# Altium schematic sheet Main.SchDoc
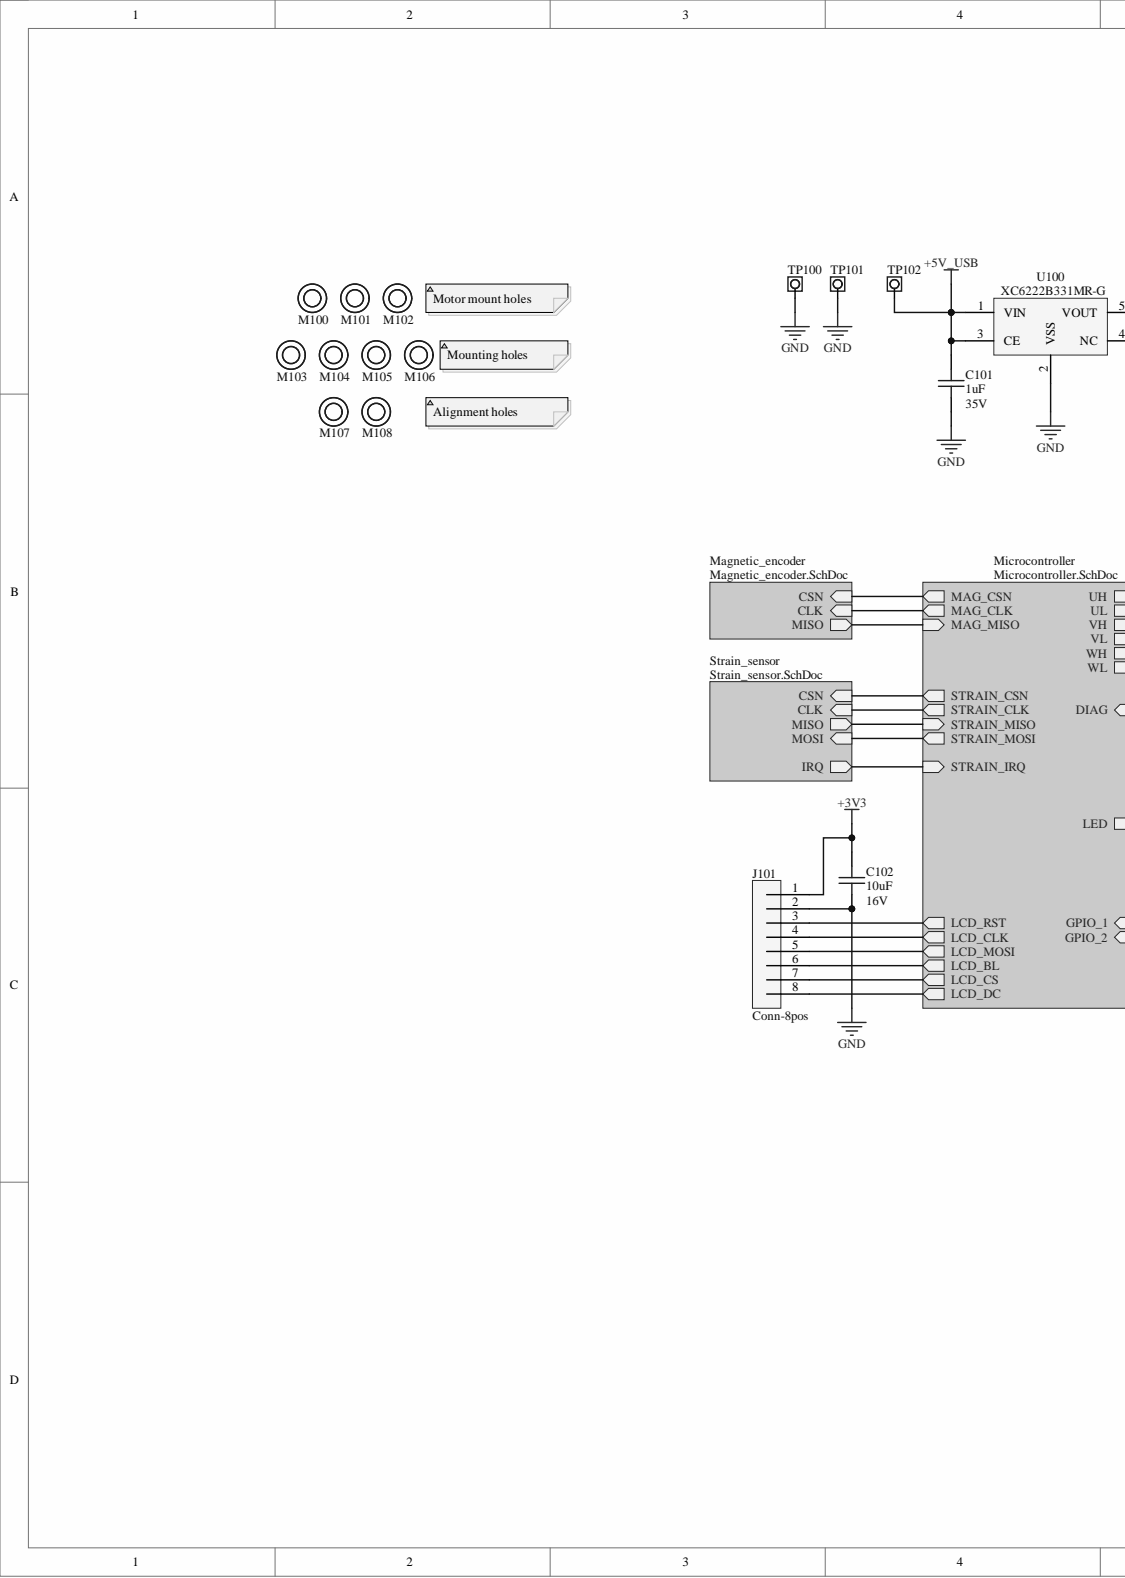
[diagram p0: Main.SchDoc, left]
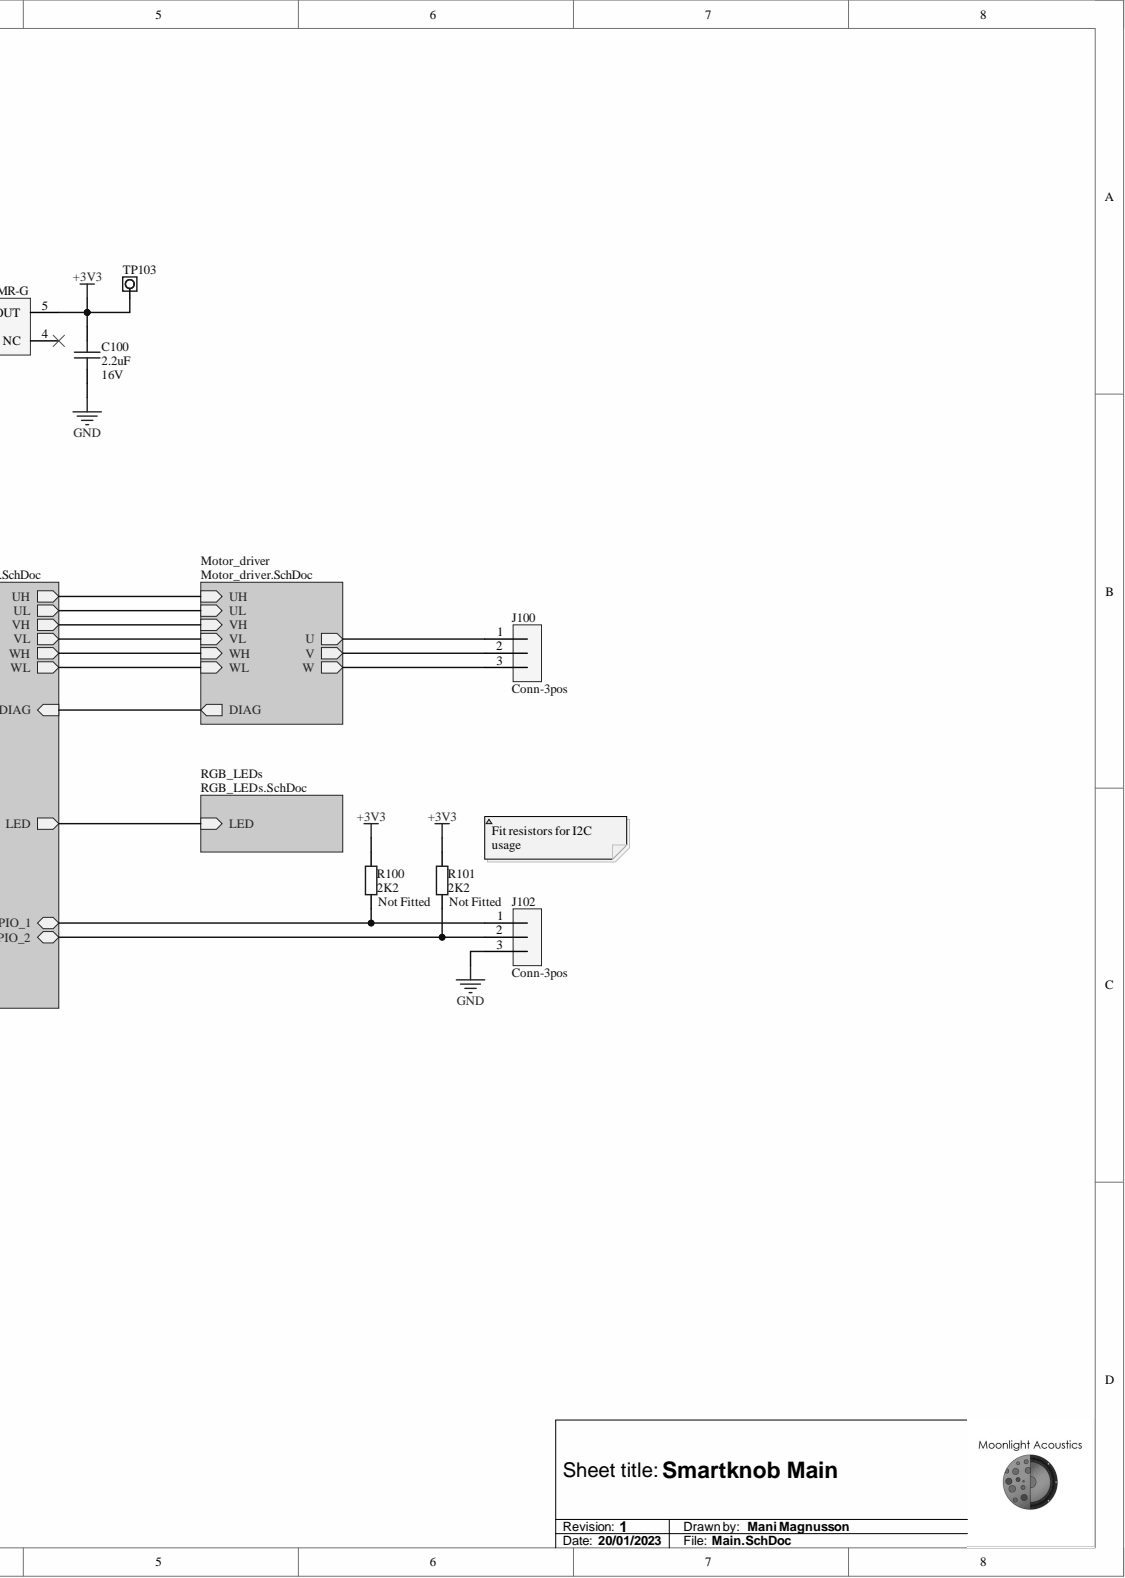
[diagram p1: Main.SchDoc, right]

## Parts
C100  value 2.2uF  Voltage: 16V  [C0805]  (hidden comment: CAP)
C101  value 1uF  Voltage: 35V  [C0603]  (hidden comment: CAP)
C102  value 10uF  Voltage: 16V  [C0805]  (hidden comment: CAP)
J100  Conn-3pos  [0532610371]
J101  Conn-8pos  [2mm_pads_8]
J102  Conn-3pos  [2.54mm_header_vertical_male]
M100  Mounting_Hole_Unplated  [M1.6_Close_Unplated]  (value not drawn)
M101  Mounting_Hole_Unplated  [M1.6_Close_Unplated]  (value not drawn)
M102  Mounting_Hole_Unplated  [M1.6_Close_Unplated]  (value not drawn)
M103  Mounting_Hole_Unplated  [M2_Normal_Unplated]  (value not drawn)
M104  Mounting_Hole_Unplated  [M2_Normal_Unplated]  (value not drawn)
M105  Mounting_Hole_Unplated  [M2_Normal_Unplated]  (value not drawn)
M106  Mounting_Hole_Unplated  [M2_Normal_Unplated]  (value not drawn)
M107  Mounting_Hole_Unplated  [M2_Close_Unplated]  (value not drawn)
M108  Mounting_Hole_Unplated  [M2_Close_Unplated]  (value not drawn)
R100  value 2K2  [R0603]  (hidden comment: RES)
R101  value 2K2  Fitted: Not Fitted  [R0603]  (hidden comment: RES)
TP100  Testpoint  [5019]  (value not drawn)
TP101  Testpoint  [5019]  (value not drawn)
TP102  Testpoint  [5019]  (value not drawn)
TP103  Testpoint  [5019]  (value not drawn)
U100  XC6222B331MR-G  [SOT-25-5]

## Nets
+3V3: C100.1 C102.1 J101.1 R100.2 R101.2 TP103.1 U100.5(VOUT)
+5V_USB: C101.1 TP102.1 U100.1(VIN) U100.3(CE)
GND: C100.2 C101.2 C102.2 J101.2 J102.3 TP100.1 TP101.1 U100.2(VSS)
NetJ100_1: J100.1
NetJ100_2: J100.2
NetJ100_3: J100.3
NetJ101_3: J101.3
NetJ101_4: J101.4
NetJ101_5: J101.5
NetJ101_6: J101.6
NetJ101_7: J101.7
NetJ101_8: J101.8
NetJ102_1: J102.1 R100.1
NetJ102_2: J102.2 R101.1
NetU100_4: U100.4(NC)
CLK:
CSN:
DIAG:
IRQ:
LED:
MAG_MISO:
MISO:
MOSI:
UH:
UL:
VH:
VL:
WH:
WL:

## Library data of the parts
C100 = CGA4J3X7R1C225K125AB: General purpose ceramic capacitor; Manufacturer: TDK Corporation; Database Table Name: Capacitors$; Ready for use: y; Specification: X7R, 16V, AEC-Q200 Qualified; Component Kind: Standard; Fitted: Fitted; Generic: Generic; Manufacturer Part No: CGA4J3X7R1C225K125AB; Package Ref: C0805; Part Reference: CGA4J3X7R1C225K125AB; Supplier: Digi-Key; Supplier Part No: 445-5691-1-ND; Library Name: Moonlight_Library.DbLib
C101 = CGA3E1X7R1V105K080AC: General purpose ceramic capacitor; Manufacturer: TDK Corporation; Database Table Name: Capacitors$; Ready for use: y; Specification: X7R, 35V, AEC-Q200 Qualified; Component Kind: Standard; Fitted: Fitted; Generic: Generic; Manufacturer Part No: CGA3E1X7R1V105K080AC; Package Ref: C0603; Part Reference: CGA3E1X7R1V105K080AC; Supplier: Digi-Key; Supplier Part No: 445-174215-1-ND; Library Name: Moonlight_Library.DbLib
C102 = CGA4J1X7S1C106K125AC: General purpose ceramic capacitor; Manufacturer: TDK Corporation; Database Table Name: Capacitors$; Ready for use: y; Specification: X7S, 16V, AEC-Q200 Qualified; Component Kind: Standard; Fitted: Fitted; Generic: Generic; Manufacturer Part No: CGA4J1X7S1C106K125AC; Package Ref: C0805; Part Reference: CGA4J1X7S1C106K125AC; Supplier: Digi-Key; Supplier Part No: 445-16110-1-ND; Library Name: Moonlight_Library.DbLib
J100 = 0532610371: 3 pin horizontal picoblade connector; Manufacturer: Molex; Database Table Name: Connectors$; Generic: Non Generic; Ready for use: y; Specification: 125 V 1 A max; Component Kind: Standard; Fitted: Fitted; Manufacturer Part No: 0532610371; Package Ref: 0532610371; Part Reference: 0532610371; Supplier: Digi-Key; Supplier Part No: WM7621CT-ND; Library Name: Moonlight_Library.DbLib
J101 = 2mm_pads_8: 2mm pitch solder pads; Database Table Name: Connectors$; Ready for use: n; Component Kind: Standard; Fitted: Fitted; Generic: Generic; Manufacturer Part No: 2mm_pads_8; Package Ref: 2mm_pads_8; Part Reference: 2mm_pads_8; Library Name: Moonlight_Library.DbLib
J102 = 61300311121: 3 pin vertical 2.54 mm header; Manufacturer: Würth Elektronik; Database Table Name: Connectors$; Ready for use: n; Specification: 250 V 3 A max; Component Kind: Standard; Fitted: Fitted; Generic: Generic; Manufacturer Part No: 61300311121; Package Ref: 2.54mm_header_vertical_male; Part Reference: 61300311121; Supplier: Digi-Key; Supplier Part No: 732-5316-ND; Library Name: Moonlight_Library.DbLib
M100-M102 = M1.6_Close_Unplated: M1.6 Hole, Unplated; Database Table Name: Non Electrical$; Ready for use: y; Specification: Close clearance; Component Kind: Standard; Fitted: Fitted; Generic: Generic; Package Ref: M1.6_Close_Unplated; Part Reference: M1.6_Close_Unplated; Library Name: Moonlight_Library.DbLib
M103-M106 = M2_Normal_Unplated: M2 Hole, Unplated; Database Table Name: Non Electrical$; Ready for use: y; Specification: Normal clearance; Component Kind: Standard; Fitted: Fitted; Generic: Generic; Package Ref: M2_Normal_Unplated; Part Reference: M2_Normal_Unplated; Library Name: Moonlight_Library.DbLib
M107 M108 = M2_Close_Unplated: M2 Hole, Unplated; Database Table Name: Non Electrical$; Ready for use: y; Specification: Close clearance; Component Kind: Standard; Fitted: Fitted; Generic: Generic; Package Ref: M2_Close_Unplated; Part Reference: M2_Close_Unplated; Library Name: Moonlight_Library.DbLib
R100 = CRCW06032K20JNEA: General purpose automotive thick film resistor; Manufacturer: Vishay Dale; Database Table Name: Resistors$; Fitted: Not Fitted; Ready for use: y; Specification: 5%, 200ppm, 0.1W, AEC-Q200 Qualified; Component Kind: Standard; Generic: Generic; Manufacturer Part No: CRCW06032K20JNEA; Package Ref: R0603; Part Reference: CRCW06032K20JNEA; Supplier: Digi-Key; Supplier Part No: 541-2.2KGCT-ND; Library Name: Moonlight_Library.DbLib
R101 = CRCW06032K20JNEA: General purpose automotive thick film resistor; Manufacturer: Vishay Dale; Database Table Name: Resistors$; Ready for use: y; Specification: 5%, 200ppm, 0.1W, AEC-Q200 Qualified; Component Kind: Standard; Generic: Generic; Manufacturer Part No: CRCW06032K20JNEA; Package Ref: R0603; Part Reference: CRCW06032K20JNEA; Supplier: Digi-Key; Supplier Part No: 541-2.2KGCT-ND; Library Name: Moonlight_Library.DbLib
TP100-TP103 = 5019: SMD Testpoint, loop style; Manufacturer: Keystone Electronics; Database Table Name: Miscellaneous$; Ready for use: y; Component Kind: Standard; Fitted: Fitted; Generic: Generic; Manufacturer Part No: 5019; Package Ref: 5019; Part Reference: 5019; Supplier: Digi-Key; Supplier Part No: 36-5019CT-ND; Library Name: Moonlight_Library.DbLib
U100 = XC6222B331MR-G: 3.3V 700mA LDO linear regulator; Manufacturer: Torex Semiconductor; Database Table Name: ICs$; Generic: Non Generic; Ready for use: y; Component Kind: Standard; Fitted: Fitted; Manufacturer Part No: XC6222B331MR-G; Package Ref: SOT-25-5; Part Reference: XC6222B331MR-G; Supplier: Digi-Key; Supplier Part No: 893-1143-1-ND; Type: PMIC; Library Name: Moonlight_Library.DbLib
